# Altium schematic sheet 02 - SOM.SchDoc
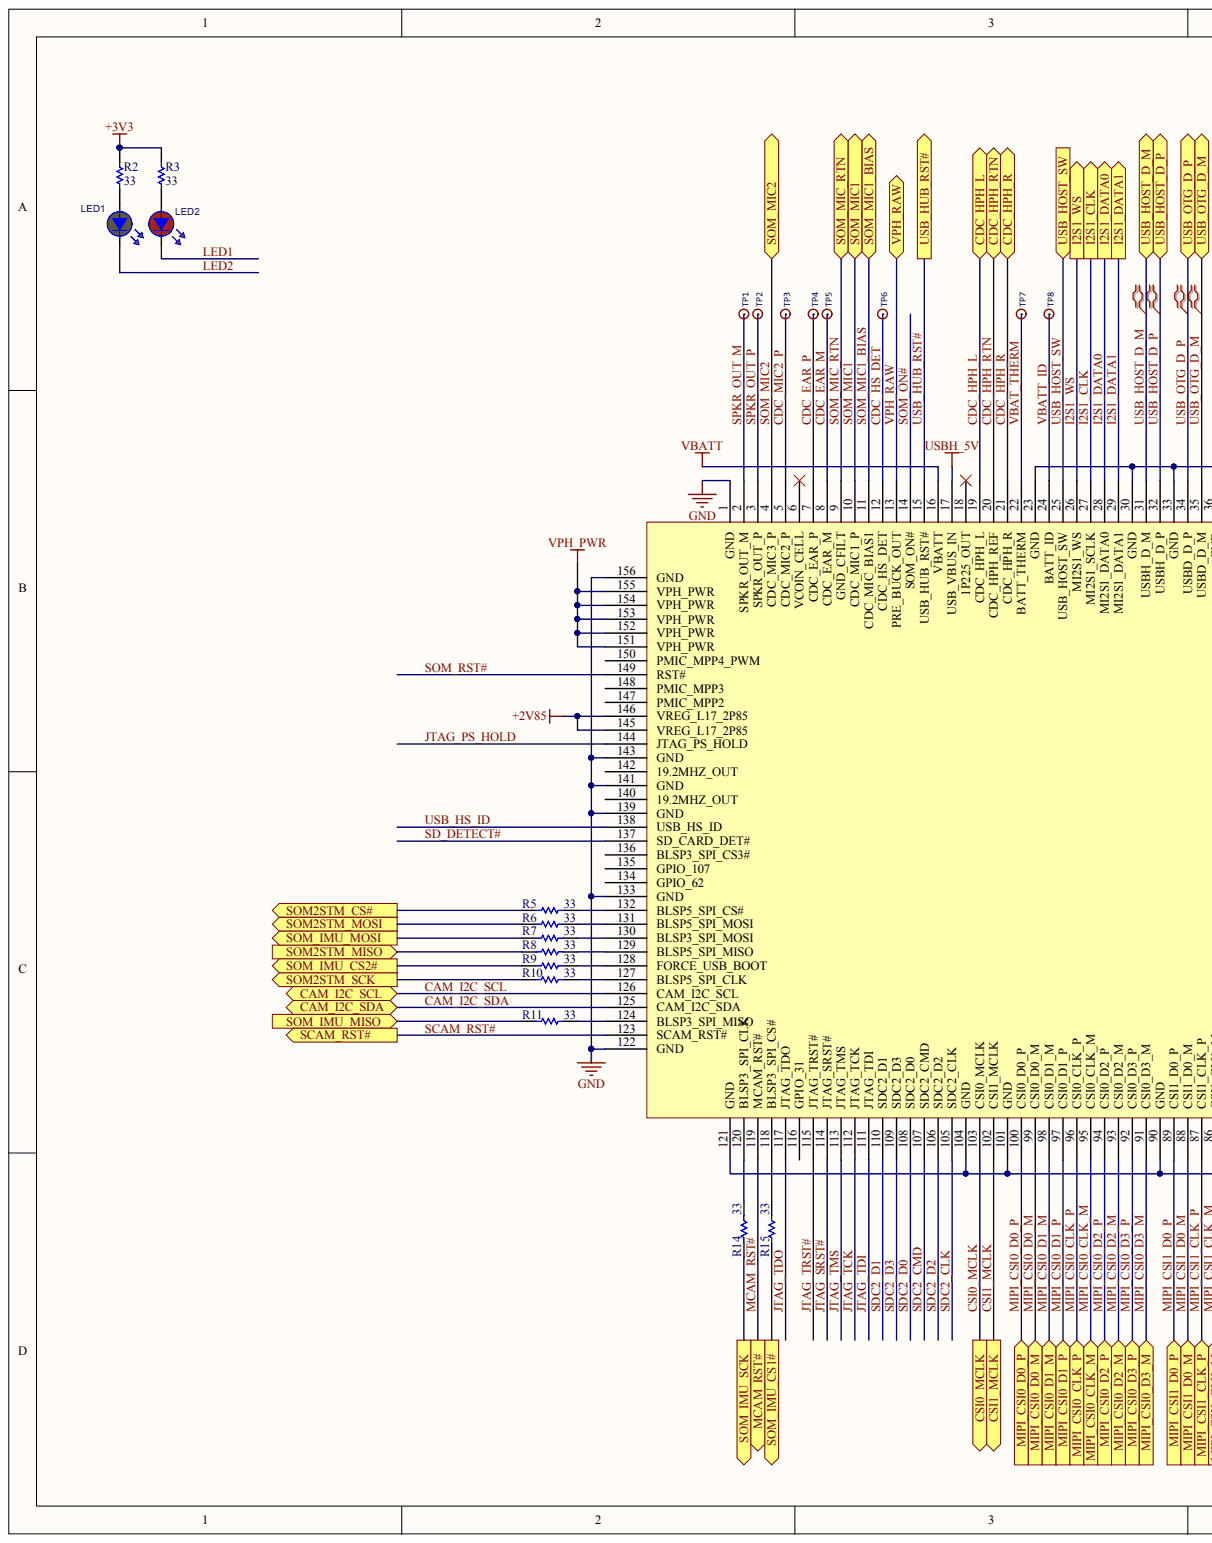
[diagram p0: 02 - SOM.SchDoc, left]
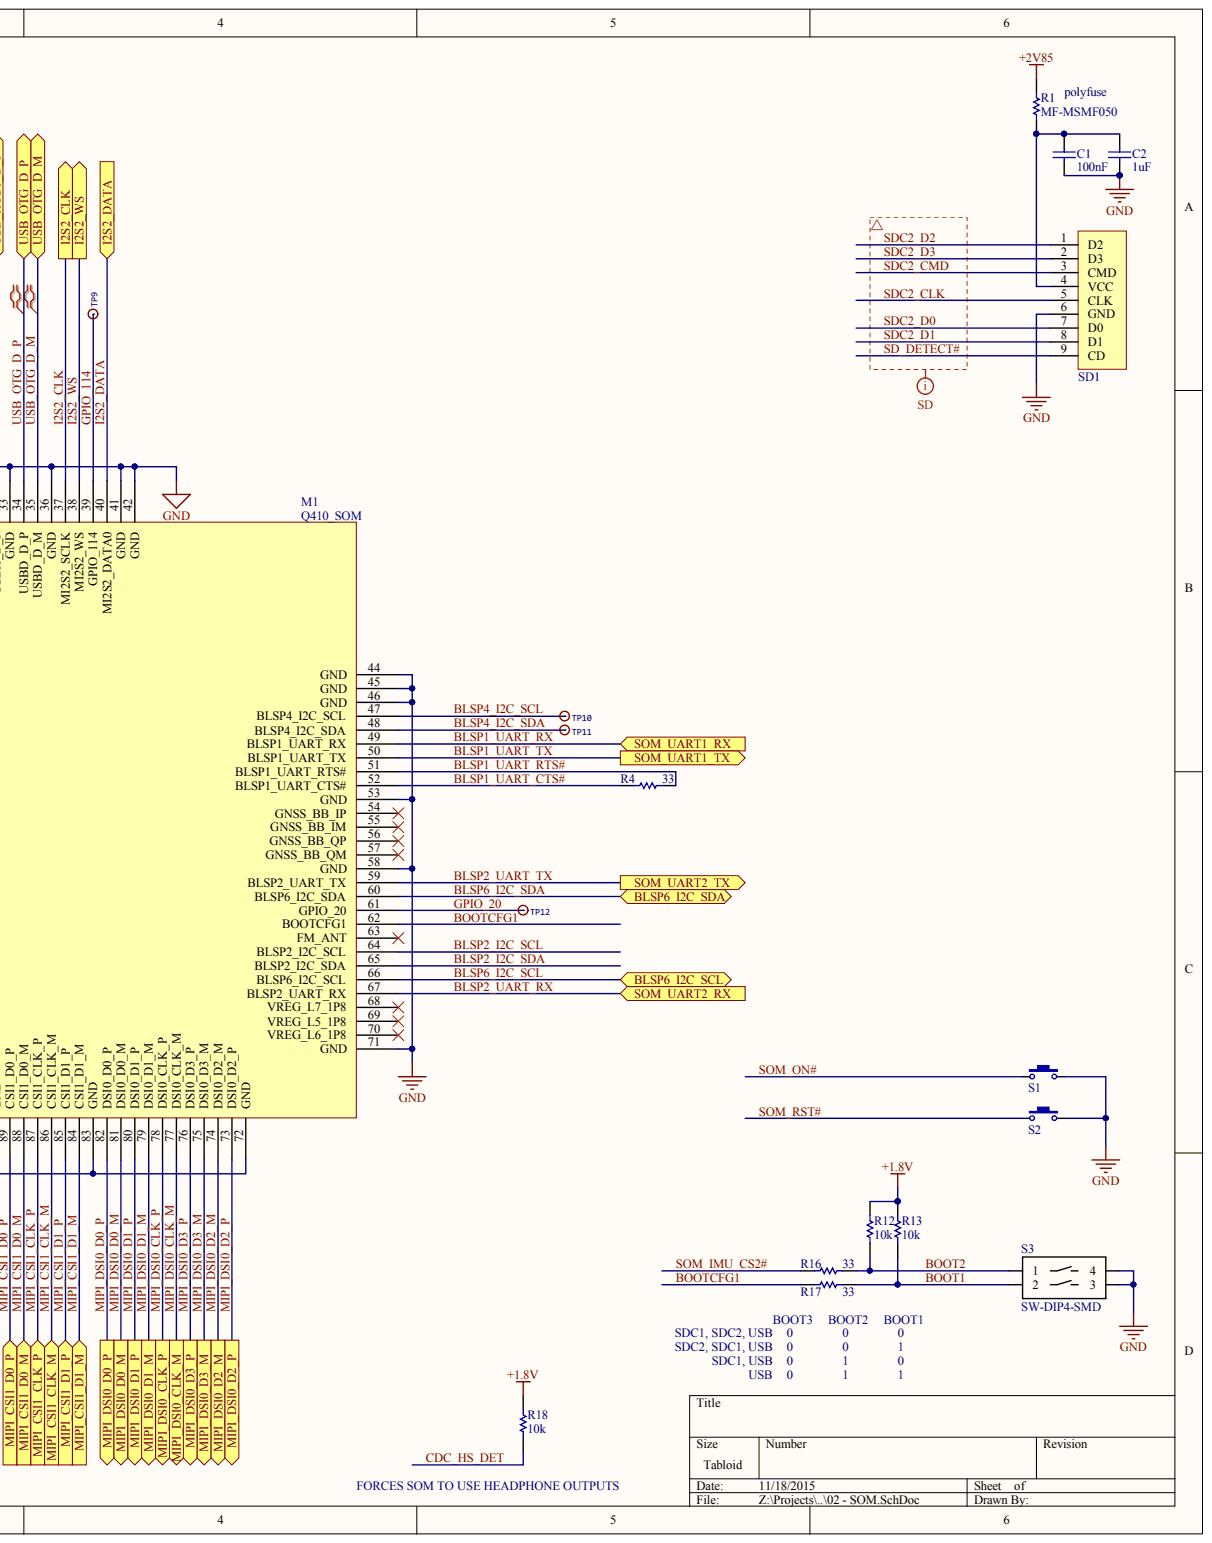
[diagram p1: 02 - SOM.SchDoc, right]

## Parts
C1  value 100nF  [CAPC0805(2012)100_N]  (hidden comment: CAP 100nF 6.3V 0805(2012))
C2  value 1uF  [CAPC0805(2012)100_M]  (hidden comment: CAP 1uF 6.3V 0805(2012))
LED1  VLMG1500-GS08  [LEDC0402(1005)_N-GRN]  (value not drawn)
LED2  VLMS1500-GS08  [LEDC0402(1005)_N-RED]  (value not drawn)
M1  Q410_SOM
R1  MF-MSMF050  [BOUR-MF-MSMF050-2_V]
R2  value 33  [RESC0805(2012)_M]
R3  value 33  [RESC0805(2012)_M]
R4  value 33  [RESC0805(2012)_M]
R5  value 33  [RESC0805(2012)_M]
R6  value 33  [RESC0805(2012)_M]
R7  value 33  [RESC0805(2012)_M]
R8  value 33  [RESC0805(2012)_M]
R9  value 33  [RESC0805(2012)_M]
R10  value 33  [RESC0805(2012)_M]
R11  value 33  [RESC0805(2012)_M]
R12  value 10k  [RESC0805(2012)_M]  (hidden comment: 10K 1% 0805(2012))
R13  value 10k  [RESC0805(2012)_M]  (hidden comment: 10K 1% 0805(2012))
R14  value 33  [RESC0805(2012)_M]
R15  value 33  [RESC0805(2012)_M]
R16  value 33  [RESC0805(2012)_M]
R17  value 33  [RESC0805(2012)_M]
R18  value 10k  [RESC0805(2012)_M]  (hidden comment: 10K 1% 0805(2012))
S1  ButtonTACT_3x4x2_180  [ButtonTACT_3x4x2_180]  (value not drawn)
S2  ButtonTACT_3x4x2_180  [ButtonTACT_3x4x2_180]  (value not drawn)
S3  SW-DIP4-SMD  [SO4SW]
SD1  SD_Card_TF  [SD_Card_TF]  (value not drawn)
TP1  (no value)  [TESTPOINT_035HOLE]
TP2  (no value)  [TESTPOINT_035HOLE]
TP3  (no value)  [TESTPOINT_035HOLE]
TP4  (no value)  [TESTPOINT_035HOLE]
TP5  (no value)  [TESTPOINT_035HOLE]
TP6  (no value)  [TESTPOINT_035HOLE]
TP7  (no value)  [TESTPOINT_035HOLE]
TP8  (no value)  [TESTPOINT_035HOLE]
TP9  (no value)  [TESTPOINT_035HOLE]
TP10  (no value)  [TESTPOINT_035HOLE]
TP11  (no value)  [TESTPOINT_035HOLE]
TP12  (no value)  [TESTPOINT_035HOLE]

## Ports
BLSP6_I2C_SCL, BLSP6_I2C_SDA, CAM_I2C_SCL, CAM_I2C_SDA, CDC_HPH_L, CDC_HPH_R, CDC_HPH_RTN, CSI0_MCLK, CSI1_MCLK, I2S1_CLK, I2S1_DATA0, I2S1_DATA1, I2S1_WS, I2S2_CLK, I2S2_DATA, I2S2_WS, MCAM_RST#, MIPI_CSI0_CLK_M, MIPI_CSI0_CLK_P, MIPI_CSI0_D0_M, MIPI_CSI0_D0_P, MIPI_CSI0_D1_M, MIPI_CSI0_D1_P, MIPI_CSI0_D2_M, MIPI_CSI0_D2_P, MIPI_CSI0_D3_M, MIPI_CSI0_D3_P, MIPI_CSI1_CLK_M, MIPI_CSI1_CLK_P, MIPI_CSI1_D0_M, MIPI_CSI1_D0_P, MIPI_CSI1_D1_M, MIPI_CSI1_D1_P, MIPI_DSI0_CLK_M, MIPI_DSI0_CLK_P, MIPI_DSI0_D0_M, MIPI_DSI0_D0_P, MIPI_DSI0_D1_M, MIPI_DSI0_D1_P, MIPI_DSI0_D2_M, MIPI_DSI0_D2_P, MIPI_DSI0_D3_M, MIPI_DSI0_D3_P, SCAM_RST#, SOM2STM_CS#, SOM2STM_MISO, SOM2STM_MOSI, SOM2STM_SCK, SOM_IMU_CS1#, SOM_IMU_CS2#, SOM_IMU_MISO, SOM_IMU_MOSI, SOM_IMU_SCK, SOM_MIC1, SOM_MIC1_BIAS, SOM_MIC2, SOM_MIC_RTN, SOM_UART1_RX, SOM_UART1_TX, SOM_UART2_RX, SOM_UART2_TX, USB_HOST_D_M, USB_HOST_D_P, USB_HOST_SW, USB_HUB_RST#, USB_OTG_D_M, USB_OTG_D_P, VPH_RAW

## Nets
+1.8V: R12.1 R13.1 R18.1
+2V85: M1.145(VREG_L17_2P85) M1.146(VREG_L17_2P85) R1.2
+3V3: R2.1 R3.1
GND: C1.2 C2.2 M1.1(GND) M1.23(GND) M1.30(GND) M1.33(GND) M1.36(GND) M1.41(GND) M1.42(GND) M1.44(GND) M1.45(GND) M1.46(GND) M1.53(GND) M1.58(GND) M1.71(GND) M1.122(GND) M1.133(GND) M1.139(GND) M1.141(GND) M1.143(GND) M1.156(GND) S1.2 S2.2 S3.3 S3.4 SD1.6(GND)
USBH_5V: M1.17(USB_VBUS_IN)
VBATT: M1.16(VBATT)
VPH_PWR: M1.151(VPH_PWR) M1.152(VPH_PWR) M1.153(VPH_PWR) M1.154(VPH_PWR) M1.155(VPH_PWR)
BLSP1_UART_CTS#: M1.52(BLSP1_UART_CTS#) R4.2
BLSP1_UART_RTS#: M1.51(BLSP1_UART_RTS#) R4.1
BLSP1_UART_RX: M1.49(BLSP1_UART_RX) ; port SOM_UART1_RX
BLSP1_UART_TX: M1.50(BLSP1_UART_TX) ; port SOM_UART1_TX
BLSP2_I2C_SCL: M1.64(BLSP2_I2C_SCL)
BLSP2_I2C_SDA: M1.65(BLSP2_I2C_SDA)
BLSP2_UART_RX: M1.67(BLSP2_UART_RX) ; port SOM_UART2_RX
BLSP2_UART_TX: M1.59(BLSP2_UART_TX) ; port SOM_UART2_TX
BLSP4_I2C_SCL: M1.47(BLSP4_I2C_SCL) TP10.1
BLSP4_I2C_SDA: M1.48(BLSP4_I2C_SDA) TP11.1
BLSP6_I2C_SCL: M1.66(BLSP6_I2C_SCL) ; port BLSP6_I2C_SCL
BLSP6_I2C_SDA: M1.60(BLSP6_I2C_SDA) ; port BLSP6_I2C_SDA
BOOT1: R13.2 R17.1 S3.2
BOOT2: R12.2 R16.1 S3.1
BOOTCFG1: M1.62(BOOTCFG1) R17.2
CAM_I2C_SCL: M1.126(CAM_I2C_SCL) ; port CAM_I2C_SCL
CAM_I2C_SDA: M1.125(CAM_I2C_SDA) ; port CAM_I2C_SDA
CDC_EAR_M: M1.8(CDC_EAR_M) TP5.1
CDC_EAR_P: M1.7(CDC_EAR_P) TP4.1
CDC_HPH_L: M1.19(CDC_HPH_L) ; port CDC_HPH_L
CDC_HPH_R: M1.21(CDC_HPH_R) ; port CDC_HPH_R
CDC_HPH_RTN: M1.20(CDC_HPH_REF) ; port CDC_HPH_RTN
CDC_HS_DET: M1.12(CDC_HS_DET) R18.2 TP6.1
CDC_MIC2_P: M1.5(CDC_MIC2_P) TP3.1
CSI0_MCLK: M1.103(CSI0_MCLK) ; port CSI0_MCLK
CSI1_MCLK: M1.102(CSI1_MCLK) ; port CSI1_MCLK
GPIO_20: M1.61(GPIO_20) TP12.1
GPIO_114: M1.39(GPIO_114) TP9.1
I2S1_CLK: M1.27(MI2S1_SCLK) ; port I2S1_CLK
I2S1_DATA0: M1.28(MI2S1_DATA0) ; port I2S1_DATA0
I2S1_DATA1: M1.29(MI2S1_DATA1) ; port I2S1_DATA1
I2S1_WS: M1.26(MI2S1_WS) ; port I2S1_WS
I2S2_CLK: M1.37(MI2S2_SCLK) ; port I2S2_CLK
I2S2_DATA: M1.40(MI2S2_DATA0) ; port I2S2_DATA
I2S2_WS: M1.38(MI2S2_WS) ; port I2S2_WS
JTAG_PS_HOLD: M1.144(JTAG_PS_HOLD)
JTAG_SRST#: M1.114(JTAG_SRST#)
JTAG_TCK: M1.112(JTAG_TCK)
JTAG_TDI: M1.111(JTAG_TDI)
JTAG_TDO: M1.117(JTAG_TDO)
JTAG_TMS: M1.113(JTAG_TMS)
JTAG_TRST#: M1.115(JTAG_TRST#)
LED1: LED2.K
LED2: LED1.K
MCAM_RST#: M1.119(MCAM_RST#) ; port MCAM_RST#
MIPI_CSI0_CLK_M: M1.95(CSI0_CLK_M) ; port MIPI_CSI0_CLK_M
MIPI_CSI0_CLK_P: M1.96(CSI0_CLK_P) ; port MIPI_CSI0_CLK_P
MIPI_CSI0_D0_M: M1.99(CSI0_D0_M) ; port MIPI_CSI0_D0_M
MIPI_CSI0_D0_P: M1.100(CSI0_D0_P) ; port MIPI_CSI0_D0_P
MIPI_CSI0_D1_M: M1.98(CSI0_D1_M) ; port MIPI_CSI0_D1_M
MIPI_CSI0_D1_P: M1.97(CSI0_D1_P) ; port MIPI_CSI0_D1_P
MIPI_CSI0_D2_M: M1.93(CSI0_D2_M) ; port MIPI_CSI0_D2_M
MIPI_CSI0_D2_P: M1.94(CSI0_D2_P) ; port MIPI_CSI0_D2_P
MIPI_CSI0_D3_M: M1.91(CSI0_D3_M) ; port MIPI_CSI0_D3_M
MIPI_CSI0_D3_P: M1.92(CSI0_D3_P) ; port MIPI_CSI0_D3_P
MIPI_CSI1_CLK_M: M1.86(CSI1_CLK_M) ; port MIPI_CSI1_CLK_M
MIPI_CSI1_CLK_P: M1.87(CSI1_CLK_P) ; port MIPI_CSI1_CLK_P
MIPI_CSI1_D0_M: M1.88(CSI1_D0_M) ; port MIPI_CSI1_D0_M
MIPI_CSI1_D0_P: M1.89(CSI1_D0_P) ; port MIPI_CSI1_D0_P
MIPI_CSI1_D1_M: M1.84(CSI1_D1_M) ; port MIPI_CSI1_D1_M
MIPI_CSI1_D1_P: M1.85(CSI1_D1_P) ; port MIPI_CSI1_D1_P
MIPI_DSI0_CLK_M: M1.77(DSI0_CLK_M) ; port MIPI_DSI0_CLK_M
MIPI_DSI0_CLK_P: M1.78(DSI0_CLK_P) ; port MIPI_DSI0_CLK_P
MIPI_DSI0_D0_M: M1.81(DSI0_D0_M) ; port MIPI_DSI0_D0_M
MIPI_DSI0_D0_P: M1.82(DSI0_D0_P) ; port MIPI_DSI0_D0_P
MIPI_DSI0_D1_M: M1.79(DSI0_D1_M) ; port MIPI_DSI0_D1_M
MIPI_DSI0_D1_P: M1.80(DSI0_D1_P) ; port MIPI_DSI0_D1_P
MIPI_DSI0_D2_M: M1.74(DSI0_D2_M) ; port MIPI_DSI0_D2_M
MIPI_DSI0_D2_P: M1.73(DSI0_D2_P) ; port MIPI_DSI0_D2_P
MIPI_DSI0_D3_M: M1.75(DSI0_D3_M) ; port MIPI_DSI0_D3_M
MIPI_DSI0_D3_P: M1.76(DSI0_D3_P) ; port MIPI_DSI0_D3_P
SCAM_RST#: M1.123(SCAM_RST#) ; port SCAM_RST#
SD_DETECT#: M1.137(SD_CARD_DET#) SD1.9(CD)
SDC2_CLK: M1.105(SDC2_CLK) SD1.5(CLK)
SDC2_CMD: M1.107(SDC2_CMD) SD1.3(CMD)
SDC2_D0: M1.108(SDC2_D0) SD1.7(D0)
SDC2_D1: M1.110(SDC2_D1) SD1.8(D1)
SDC2_D2: M1.106(SDC2_D2) SD1.1(D2)
SDC2_D3: M1.109(SDC2_D3) SD1.2(D3)
SOM2STM_CS#: R5.2 ; port SOM2STM_CS#
SOM2STM_MISO: R8.2 ; port SOM2STM_MISO
SOM2STM_MOSI: R6.2 ; port SOM2STM_MOSI
SOM2STM_SCK: R10.2 ; port SOM2STM_SCK
SOM_IMU_CS1#: R15.2 ; port SOM_IMU_CS1#
SOM_IMU_CS2#: R16.2
SOM_IMU_MISO: R11.2 ; port SOM_IMU_MISO
SOM_IMU_MOSI: R7.2 ; port SOM_IMU_MOSI
SOM_IMU_SCK: R14.2 ; port SOM_IMU_SCK
SOM_MIC1: M1.10(CDC_MIC1_P) ; port SOM_MIC1
SOM_MIC1_BIAS: M1.11(CDC_MIC_BIAS1) ; port SOM_MIC1_BIAS
SOM_MIC2: M1.4(CDC_MIC3_P) ; port SOM_MIC2
SOM_MIC_RTN: M1.9(GND_CFILT) ; port SOM_MIC_RTN
SOM_ON#: M1.14(SOM_ON#) S1.1
SOM_RST#: M1.149(RST#) S2.1
SPKR_OUT_M: M1.2(SPKR_OUT_M) TP1.1
SPKR_OUT_P: M1.3(SPKR_OUT_P) TP2.1
USB_HOST_D_M: M1.31(USBH_D_M) ; port USB_HOST_D_M
USB_HOST_D_P: M1.32(USBH_D_P) ; port USB_HOST_D_P
USB_HOST_SW: M1.25(USB_HOST_SW) ; port USB_HOST_SW
USB_HS_ID: M1.138(USB_HS_ID)
USB_HUB_RST#: M1.15(USB_HUB_RST#) ; port USB_HUB_RST#
USB_OTG_D_M: M1.35(USBD_D_M) ; port USB_OTG_D_M
USB_OTG_D_P: M1.34(USBD_D_P) ; port USB_OTG_D_P
VBAT_THERM: M1.22(BATT_THERM) TP7.1
VBATT_ID: M1.24(BATT_ID) TP8.1
VPH_RAW: M1.13(PRE_BUCK_OUT) ; port VPH_RAW
NetC1_1: C1.1 C2.1 R1.1 SD1.4(VCC)
NetLED1_A: LED1.A R2.2
NetLED2_A: LED2.A R3.2
NetM1_72: M1.72(GND) M1.83(GND) M1.90(GND) M1.101(GND) M1.104(GND) M1.121(GND)
NetM1_118: M1.118(BLSP3_SPI_CS#) R15.1
NetM1_120: M1.120(BLSP3_SPI_CLK) R14.1
NetM1_124: M1.124(BLSP3_SPI_MISO) R11.1
NetM1_127: M1.127(BLSP5_SPI_CLK) R10.1
NetM1_128: M1.128(FORCE_USB_BOOT) R9.1
NetM1_129: M1.129(BLSP5_SPI_MISO) R8.1
NetM1_130: M1.130(BLSP3_SPI_MOSI) R7.1
NetM1_131: M1.131(BLSP5_SPI_MOSI) R6.1
NetM1_132: M1.132(BLSP5_SPI_CS#) R5.1
NetR9_2: R9.2 ; port SOM_IMU_CS2#
N00076:

Not connected: M1 6(VCOIN_CELL) 18(1P225_OUT) 54(GNSS_BB_IP) 55(GNSS_BB_IM) 56(GNSS_BB_QP) 57(GNSS_BB_QM) 63(FM_ANT) 68(VREG_L7_1P8) 69(VREG_L5_1P8) 70(VREG_L6_1P8) 116(GPIO_31) 134(GPIO_62) 135(GPIO_107) 136(BLSP3_SPI_CS3#) 140(19.2MHZ_OUT) 142(19.2MHZ_OUT) 147(PMIC_MPP2) 148(PMIC_MPP3) 150(PMIC_MPP4_PWM)

## Library data of the parts
C1 = CMP-1036-04391-1: CAP 100nF 6.3V Â±10% 0805 (2012 Metric) Thickness 1mm SMD; Case-EIA: 0805; Case-Metric: 2012; Component Kind: Standard; Library Name: Altium Content Vault; Max Thickness: 1 mm; Rated Voltage: 6.3 V; Technology: SMT; Tolerance: Â±10%
C2 = CMP-1036-04730-1: CAP 1uF 6.3V Â±5% 0805 (2012 Metric) Thickness 1mm SMD; Case-EIA: 0805; Case-Metric: 2012; Component Kind: Standard; Library Name: Altium Content Vault; Max Thickness: 1 mm; Rated Voltage: 6.3 V; Technology: SMT; Tolerance: Â±5%
LED1 = LED_0402_VLMG1500-GS08: LED GREEN 0402 SMD; Manufacturer: Vishay Semiconductor Opto Division; Current - Test: 20mA; Lens Style/Size: Rectangle with Flat Top, 1.00mm x 0.50mm; Millicandela Rating: 35mcd; Viewing Angle: 130°; Voltage - Forward (Vf) (Typ): 2V; Wavelength - Dominant: 571nm; Wavelength - Peak: 574nm; Category: Optoelectronics; Color: Green; Component Kind: Standard; ComponentLink1Description: http://www.vishay.com/docs/82554/vlmo1500.pdf; ComponentLink1URL: http://www.vishay.com/docs/82554/vlmo1500.pdf; Height (Max): 0.35mm; Lens Color: -; Lens Transparency: -; Library Name: RevAir32.IntLib; Manufacturer Part Number: VLMG1500-GS08; Mounting Type: Surface Mount; Package / Case: 0402 (1005 Metric); Packaging: Cut Tape (CT); Pricing 1: 1=0.52, 10=0.384, 25=0.3072, 100=0.2035, 250=0.144, 500=0.12288, 750=0.10368, 1000=0.096 (USD); RoHS: RoHS Compliant; Size / Dimension: 1.00mm L x 0.50mm W; Stock 1: 37065; Supplier 1: Digi-Key; Supplier Device Package: 0402; Supplier Part Number 1: VLMG1500-GS08CT-ND
LED2 = LED_0402_VLMS1500-GS08: LED RED 0402 SMD; Manufacturer: Vishay Semiconductor Opto Division; Current - Test: 20mA; Lens Style/Size: Rectangle with Flat Top, 1.00mm x 0.50mm; Millicandela Rating: 54mcd; Viewing Angle: 130°; Voltage - Forward (Vf) (Typ): 2V; Wavelength - Dominant: 631nm; Wavelength - Peak: 639nm; Category: Optoelectronics; Color: Red; Component Kind: Standard; ComponentLink1Description: http://www.vishay.com/docs/82554/vlmo1500.pdf; ComponentLink1URL: http://www.vishay.com/docs/82554/vlmo1500.pdf; Height (Max): 0.35mm; Lens Color: -; Lens Transparency: -; Library Name: RevAir32.IntLib; Manufacturer Part Number: VLMS1500-GS08; Mounting Type: Surface Mount; Package / Case: 0402 (1005 Metric); Packaging: Cut Tape (CT); Pricing 1: 1=0.52, 10=0.384, 25=0.3072, 100=0.2035, 250=0.144, 500=0.12288, 750=0.10368, 1000=0.096 (USD); RoHS: RoHS Compliant; Size / Dimension: 1.00mm L x 0.50mm W; Stock 1: 41406; Supplier 1: Digi-Key; Supplier Device Package: 0402; Supplier Part Number 1: VLMS1500-GS08CT-ND
M1 = Q410: Component Kind: Standard; Library Name: q410som.SchLib
R1 = CMP-0280-00002-2: MF-MSMF Series - PTC Resettable Fuse, 15 V Max, 0.15 Ohm Min, -40 to 85 degC, RoHS, Reel; Manufacturer: Bourns; Current Rating (Max): 100 A; Hold Current: 0.5 A; Trip Current: 1 A; Voltage DC (Max): 15 V; Component Kind: Standard; ComponentLink1Description: Manufacturer URL; ComponentLink1URL: http://www.bourns.com/; ComponentLink2Description: Datasheet; ComponentLink2URL: http://www.bourns.com/data/global/pdfs/mfmsmf.pdf; ComponentLink3Description: Package Specification; ComponentLink3URL: http://www.bourns.com/data/global/pdfs/mfmsmf.pdf; DatasheetVersion: Rev. AD; Library Name: Altium Content Vault; Mounting Technology: Surface Mount; Operating Temperature Range: -40 to 85 degC; PackageDescription: 2-Pin SMD, Body 4.55 x 3.24 mm; PackageReference: MF-MSMF050; Packing: Reel; PartNumber: MF-MSMF050; Resistance (Min): 0.15 Ohm; RoHS: TRUE
R2-R18 = CMP-1013-00623-1: 10K 0.125W 1% 0805 (2012 Metric)  SMD; Case-EIA: 0805; Case-Metric: 2012; Component Kind: Standard; Library Name: Altium Inc., Content Vault; Power: 0.125 W; Technology: SMT; Tolerance: 1 %
S1 S2 = ButtonTACT_3x4x2_180: Button; Component Kind: Standard; Library Name: SCH_Switch.SchLib
S3 = SW-DIP4-SMD: 2 POLE DIP SWITCH SMD; Component Kind: Standard; Library Name: vh_altium_library.intLib
SD1 = SD_Card_TF: SD; Component Kind: Standard; Library Name: SCH_SDCard.SchLib
TP1-TP12 = TESTPOINTHOLE035: Component Kind: Standard; Library Name: ak-misc.SCHLIB
